# Altium schematic sheet Sheet2.SchDoc(Sheet2)
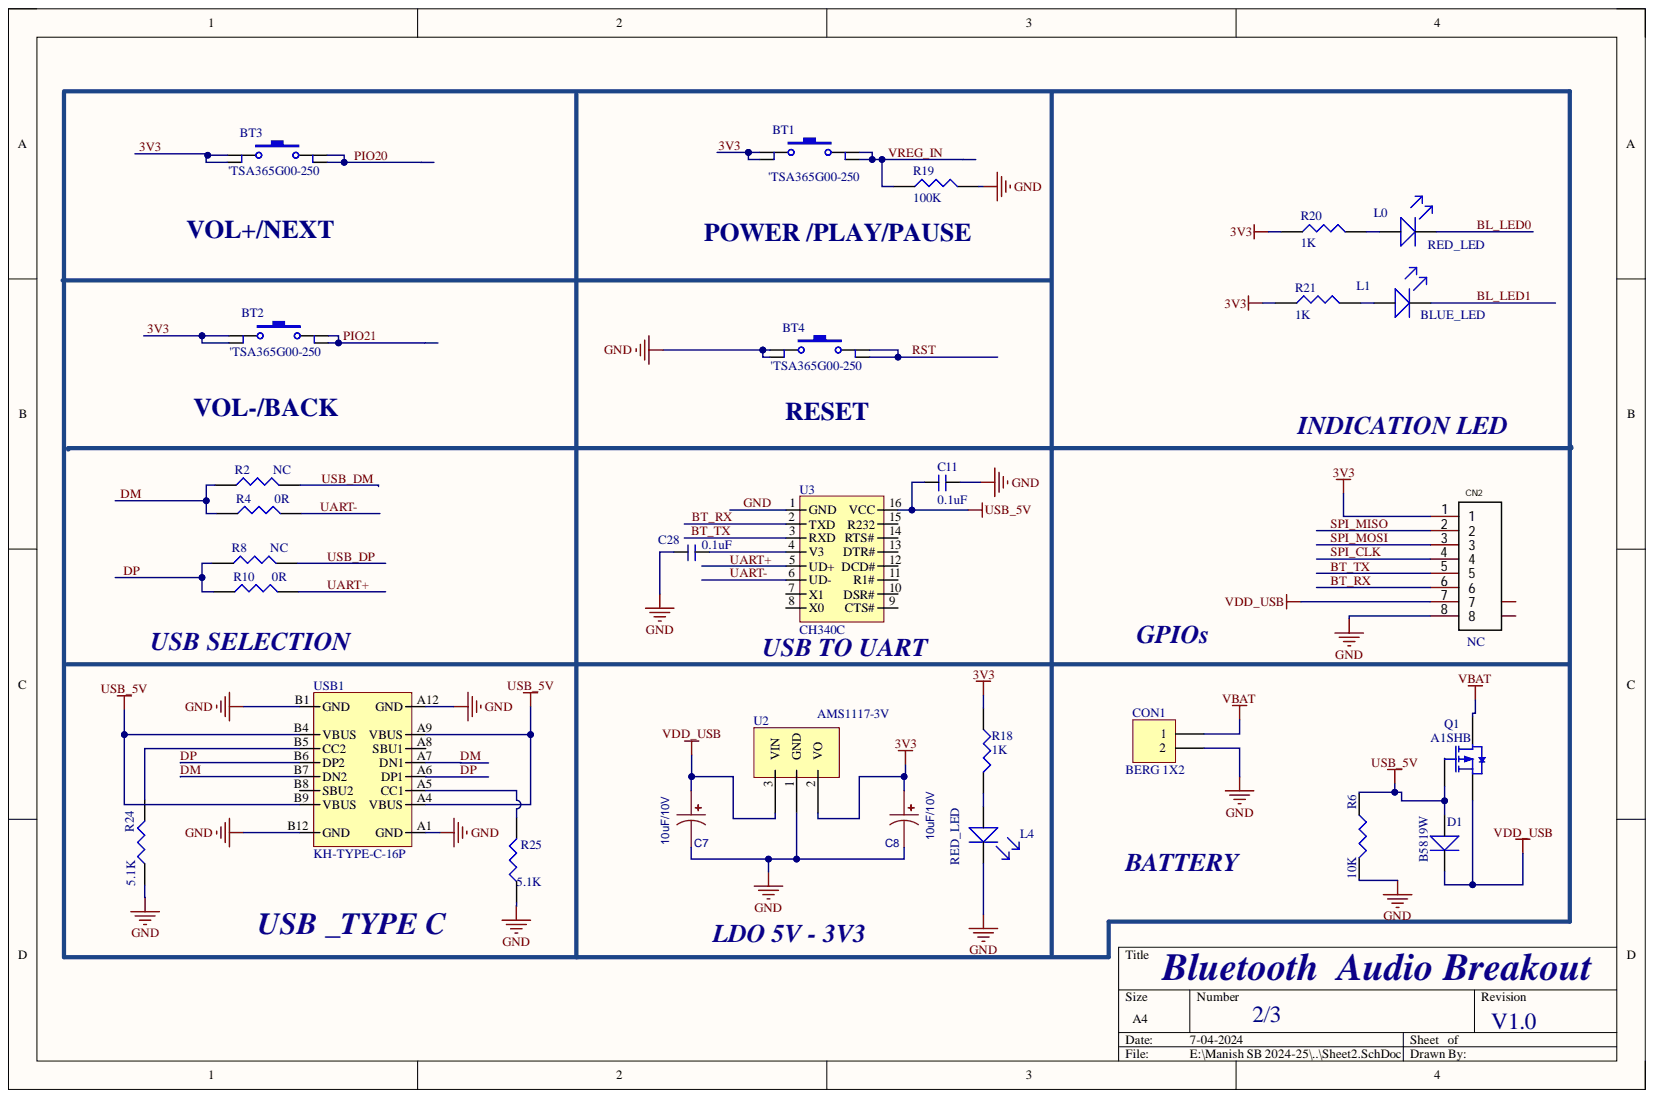
[diagram p0: Sheet2.SchDoc]

## Parts
BT1  'TSA365G00-250  [TACT_]
BT2  'TSA365G00-250  [TACT_]
BT3  'TSA365G00-250  [TACT_]
BT4  'TSA365G00-250  [TACT_]
C7  10uF/10V  [Tantlum_A_3216]
C8  10uF/10V  [Tantlum_A_3216]
C11  0.1uF  [C_0603]
C28  0.1uF  [C_0603]
CN2  1.0T-8P卧贴  [SH 1X8P 1MM]  (value not drawn)
CON1  BERG 1X2  [2P_2.54MM]
D1  B5819W  [SOD-123]
L0  RED_LED  [LED_0603]
L1  BLUE_LED  [LED_0603]
L4  RED_LED  [LED_0603]
Q1  A1SHB  [BSS84]
R2  NC  [R_0603]
R4  0R  [R_0603]
R6  10K  [R_0603]
R8  NC  [R_0603]
R10  0R  [R_0603]
R18  1K  [R_0603]
R19  100K  [R_0603]
R20  1K  [R_0603]
R21  1K  [R_0603]
R24  5.1K  [R_0603]
R25  5.1K  [R_0603]
U2  AMS1117-3V  [AMS1117_3V3]
U3  CH340C  [SOIC_16]
USB1  KH-TYPE-C-16P  [USB TYPE _C]

## Nets
USB_5V: C11.1 D1.A Q1.1 R6.1 U3.16(VCC) USB1.A4(VBUS) USB1.A9(VBUS) USB1.B4(VBUS) USB1.B9(VBUS)
VBAT: CON1.1 Q1.3
VDD_USB: C7.1 CN2.7 D1.K Q1.2 U2.3
3V3: BT1.1 BT1.2 BT2.1 BT2.2 BT3.1 BT3.2 C8.1 CN2.1 R18.1 R20.1 R21.1 U2.2
BL_LED0: L0.K
BL_LED1: L1.K
BT_RX: CN2.6 U3.2(TXD)
BT_TX: CN2.5 U3.3(RXD)
DM: R2.1 R4.1 USB1.A7(DN1) USB1.B7(DN2)
DP: R8.1 R10.1 USB1.A6(DP1) USB1.B6(DP2)
GND: BT4.1 BT4.2 C7.2 C8.2 C11.2 C28.1 CN2.8 CON1.2 L4.K R6.2 R19.2 R24.1 R25.1 U2.1 U3.1(GND) USB1.A1(GND) USB1.A12(GND) USB1.B1(GND) USB1.B12(GND)
PIO20: BT3.3 BT3.4
PIO21: BT2.3 BT2.4
RST: BT4.3 BT4.4
SPI_CLK: CN2.4
SPI_MISO: CN2.2
SPI_MOSI: CN2.3
UART+: R10.2 U3.5(UD+)
UART-: R4.2 U3.6(UD-)
USB_DM: R2.2
USB_DP: R8.2
VREG_IN: BT1.3 BT1.4 R19.1
NetC28_2: C28.2 U3.4(V3)
NetL0_A: L0.A R20.2
NetL1_A: L1.A R21.2
NetL4_A: L4.A R18.2
NetR24_2: R24.2 USB1.B5(CC2)
NetR25_2: R25.2 USB1.A5(CC1)

Not connected: CN2 9 10; U3 7(X1) 8(X0) 9(CTS#) 10(DSR#) 11(R1#) 12(DCD#) 13(DTR#) 14(RTS#) 15(R232); USB1 A8(SBU1) B8(SBU2)

## Library data of the parts
BT1-BT4 = TACTILE_SWITCH: Component Kind: Standard; Library Name: dxp_pasl_lib.IntLib
C7 C8 = 270uF: BOM_Manufacturer: rubycon(红宝石); BOM_Manufacturer Part: 100ZL270MEFC12.5X35; Contributor: lcsc; nameAlias: Capacitance; BOM_JLCPCB Part Class: Extended Part; BOM_Supplier: LCSC; BOM_Supplier Part: C1598036; Component Kind: Standard; link: https://atta.szlcsc.com/upload/public/pdf/source/20210810/D32E969E718DCFB364F518B2A745C406.pdf; spicePre: C; spiceSymbolName: 100ZL270MEFC12.5X35; Library Name: MIX.SchLib
C11 C28 = CAP_NONPOLAR: Component Kind: Standard; Library Name: dxp_pasl_lib.IntLib
CN2 = 1.0T-8P卧贴: Manufacturer: BOOMELE(博穆精密); Add into BOM: yes; Number of PINs Per Row: 8; Pins Structure: 1x8P; Pitch: 1mm; Reference Series: SH; Symbol: 1.0T 8P; Component Kind: Standard; Contact Material: -; Convert to PCB: yes; Datasheet: https://atta.szlcsc.com/upload/public/pdf/source/20151208/1457707616463.pdf; Device: 1.0T-8P卧贴; JLCPCB Part Class: Extended Part; LCSC Part Name: 系列:SH 间距:1mm 1x8P; Manufacturer Part: 1.0T-8P卧贴; Mounting Style: -; Number of Pins: 8P; Number of Rows: 1; Row Spacing: -; Supplier: LCSC; Supplier Footprint: SMD,P=1mm; Supplier Part: C49633
CON1 = HEADER 1X2: Component Kind: Standard; Library Name: SCH LIB.SchLib
D1 = DIODE: Component Kind: Standard; Library Name: dxp_pasl_lib.IntLib
L0 L1 L4 = LED: Component Kind: Standard; Library Name: dxp_pasl_lib.IntLib
Q1 = BSS84: 50V 130mA 250mW 10Ω 10V,130mA 2V 1mA P Channel SOT-23(SOT-23-3) MOSFETs ROHS #C493579; PackageDocument: 1995/1996; CATEGORY: MOSFET; Component Kind: Standard; LatestRevisionDate: 17-Jul-2002; LatestRevisionNote: Re-released for DXP Platform.; PackageReference: E3; Published: 8-Jun-2000; Library Name: dxp_pasl_lib.IntLib; Publisher: Altium Limited
R2 R4 R6 R8 R10 R18-R21 R24 R25 = RES: Component Kind: Standard; Library Name: dxp_pasl_lib.IntLib
U2 = AMS1117: Component Kind: Standard; Library Name: dxp_pasl_lib.IntLib
USB1 = USB TYPE-C KH-TYPE-C-16P: 3A 1 Surface Mount 16 Female -40?~+85? Type-C SMD USB Connectors ROHS #  C709357; Component Kind: Standard; ComponentLink2Description: New link; ComponentLink2URL: https://lcsc.com/product-detail/USB-Connectors_Shenzhen-Kinghelm-Elec-KH-TYPE-C-16P_C709357.html; Supplier 1: LCSC; Supplier Part Number 1: C165948; Library Name: dxp_pasl_lib.IntLib
U3 = CH340C: Component Kind: Standard; Library Name: dxp_pasl_lib.IntLib
